# Altium schematic sheet MCU_Power.SchDoc(MCU_Power)
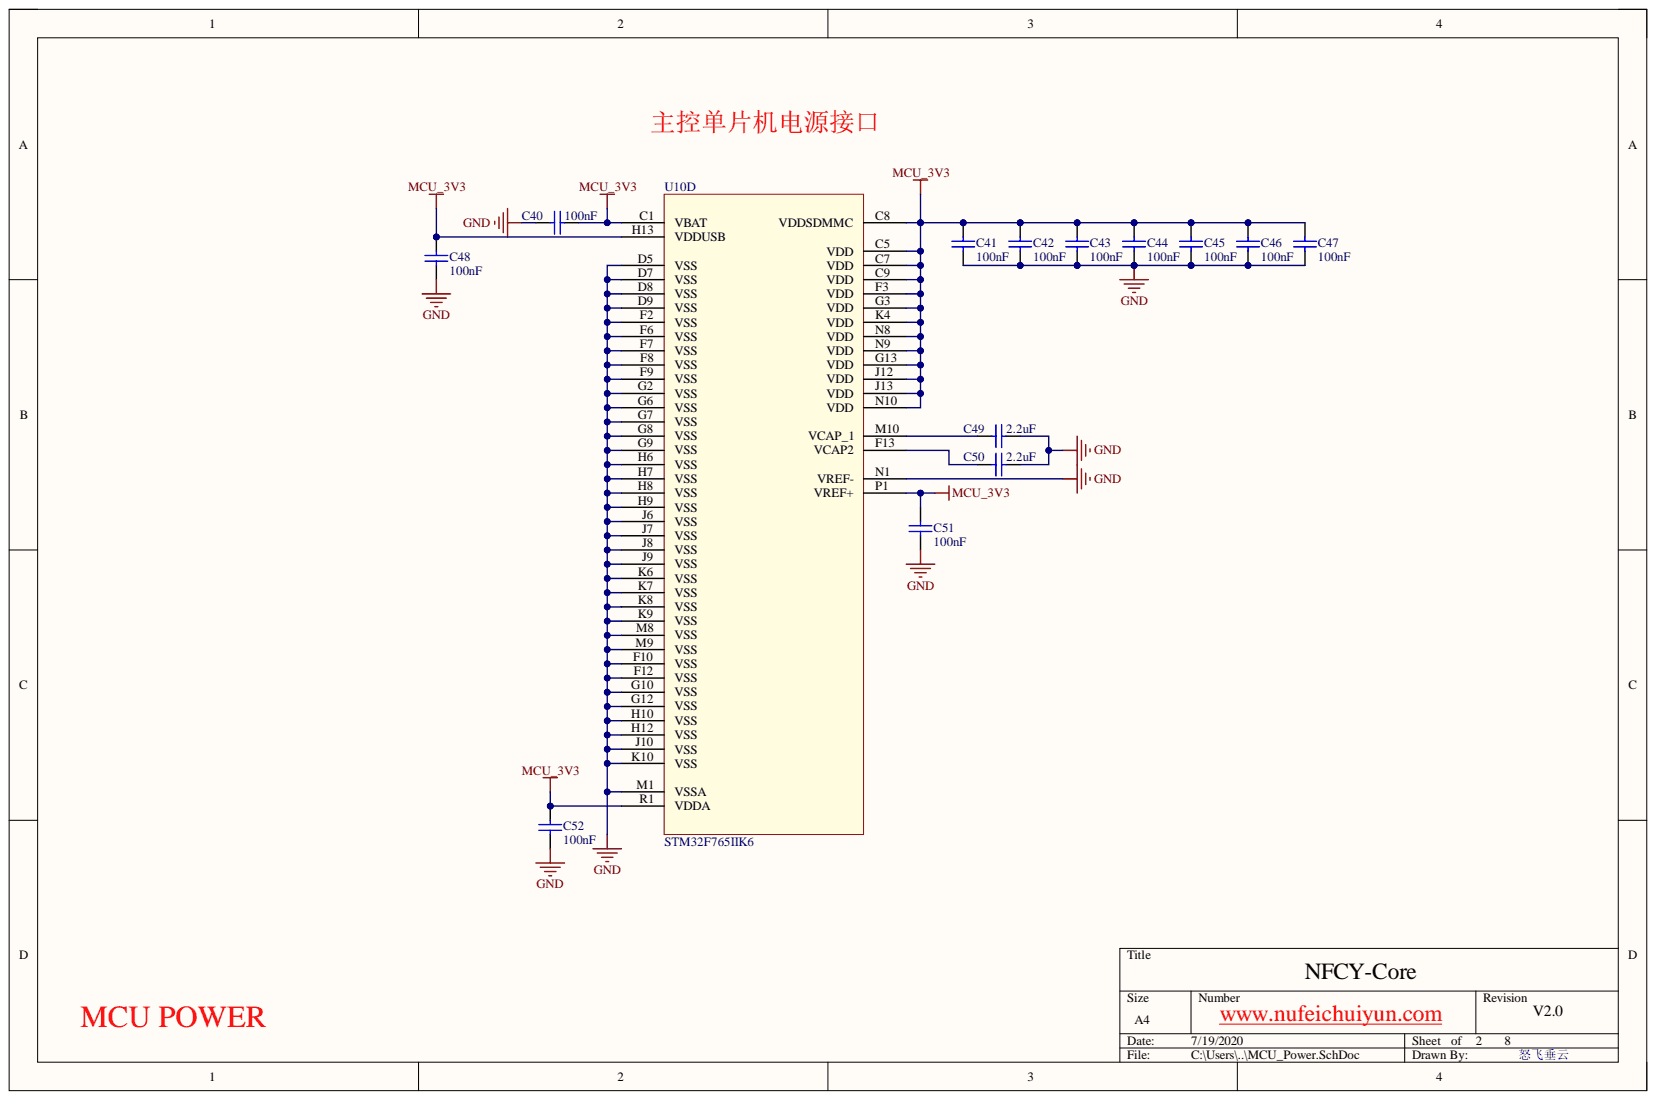
[diagram p0: MCU_Power.SchDoc]

## Parts
C40  value 100nF  [CAP0402]  (hidden comment: CL05B104KO5NNNC)
C41  value 100nF  [CAP0402]  (hidden comment: CL05B104KO5NNNC)
C42  value 100nF  [CAP0402]  (hidden comment: CL05B104KO5NNNC)
C43  value 100nF  [CAP0402]  (hidden comment: CL05B104KO5NNNC)
C44  value 100nF  [CAP0402]  (hidden comment: CL05B104KO5NNNC)
C45  value 100nF  [CAP0402]  (hidden comment: CL05B104KO5NNNC)
C46  value 100nF  [CAP0402]  (hidden comment: CL05B104KO5NNNC)
C47  value 100nF  [CAP0402]  (hidden comment: CL05B104KO5NNNC)
C48  value 100nF  [CAP0402]  (hidden comment: CL05B104KO5NNNC)
C49  value 2.2uF  [CAP0402]  (hidden comment: CL05A225KP5NSNC)
C50  value 2.2uF  [CAP0402]  (hidden comment: CL05A225KP5NSNC)
C51  value 100nF  [CAP0402]  (hidden comment: CL05B104KO5NNNC)
C52  value 100nF  [CAP0402]  (hidden comment: CL05B104KO5NNNC)
U10  STM32F765IIK6  [UFBGA176+25]  (part D)

## Nets
GND: C40.2 C41.2 C42.2 C43.2 C44.2 C45.2 C46.2 C47.2 C48.2 C49.1 C50.1 C51.2 C52.2 U10.D5(VSS) U10.D7(VSS) U10.D8(VSS) U10.D9(VSS) U10.F2(VSS) U10.F6(VSS) U10.F7(VSS) U10.F8(VSS) U10.F9(VSS) U10.F10(VSS) U10.F12(VSS) U10.G2(VSS) U10.G6(VSS) U10.G7(VSS) U10.G8(VSS) U10.G9(VSS) U10.G10(VSS) U10.G12(VSS) U10.H6(VSS) U10.H7(VSS) U10.H8(VSS) U10.H9(VSS) U10.H10(VSS) U10.H12(VSS) U10.J6(VSS) U10.J7(VSS) U10.J8(VSS) U10.J9(VSS) U10.J10(VSS) U10.K6(VSS) U10.K7(VSS) U10.K8(VSS) U10.K9(VSS) U10.K10(VSS) U10.M1(VSSA) U10.M8(VSS) U10.M9(VSS) U10.N1(VREF-)
MCU_3V3: C40.1 C41.1 C42.1 C43.1 C44.1 C45.1 C46.1 C47.1 C48.1 C51.1 C52.1 U10.C1(VBAT) U10.C5(VDD) U10.C7(VDD) U10.C8(VDDSDMMC) U10.C9(VDD) U10.F3(VDD) U10.G3(VDD) U10.G13(VDD) U10.H13(VDDUSB) U10.J12(VDD) U10.J13(VDD) U10.K4(VDD) U10.N8(VDD) U10.N9(VDD) U10.N10(VDD) U10.P1(VREF+) U10.R1(VDDA)
NetC49_2: C49.2 U10.M10(VCAP_1)
NetC50_2: C50.2 U10.F13(VCAP2)

## Library data of the parts
C40-C52 = Cap: 贴片电容; manufacturer: SAMSUNG(三星); Component Kind: Standard; LatestRevisionDate: 17-Jul-2002; LatestRevisionNote: Re-released for DXP Platform.; Library Name: Miscellaneous Devices.IntLib; PackageDescription: Radial Cap, Thru-Hole; 2 Leads; 0.3 in Pin Spacing; PackageReference: RAD-0.3; Published: 8-Jun-2000; Signal Integrity: Cap; Simulation: CAP; Publisher: Altium Limited
U10 = STM32F765IIK6: STM32F7; manufacturer: STMicroelectronics; Manufacturer_Part_Number: stm32f76xixk; C: Copyright (C) 2016 Accelerated Designs. All rights reserved; Component Kind: Standard; Library Name: STM32F765.IntLib; RefDes: RefDes; Type: Type; Value: Value; Vendor: STMicroelectronics; Copyright: Copyright (C) 2016 Accelerated Designs. All rights reserved
